# Altium schematic sheet SIM.SchDoc
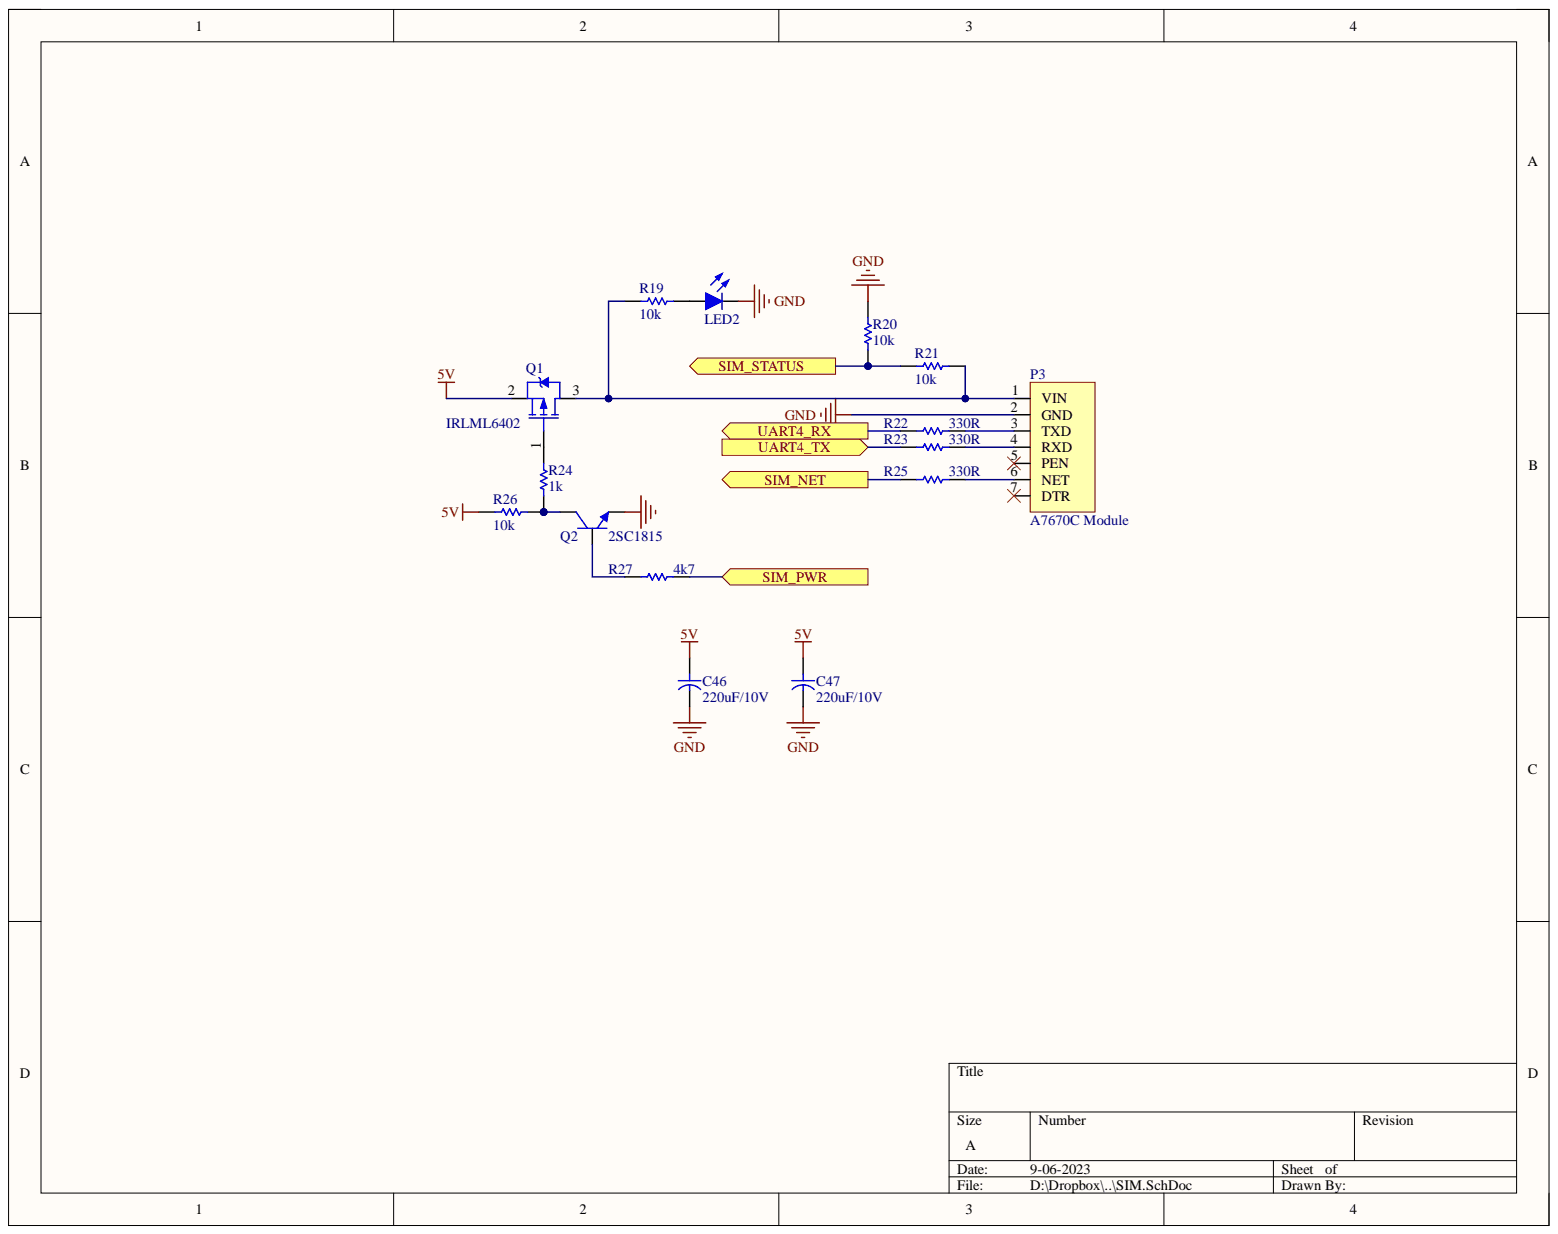
[diagram p0: SIM.SchDoc]

## Parts
C46  220uF/10V  [C SMD ALU (D)]
C47  220uF/10V  [C SMD ALU (D)]
LED2  Led-Red  [Led SMD 0603 RED]  (value not drawn)
P3  A7670C Module  [A7670C MODULE]
Q1  IRLML6402  [SOT-23]
Q2  2SC1815  [SOT-23]
R19  10k  [R 0603 (1608)]
R20  10k  [R 0603 (1608)]
R21  10k  [R 0603 (1608)]
R22  330R  [R 0603 (1608)]
R23  330R  [R 0603 (1608)]
R24  1k  [R 0603 (1608)]
R25  330R  [R 0603 (1608)]
R26  10k  [R 0603 (1608)]
R27  4k7  [R 0603 (1608)]

## Ports
SIM_NET, SIM_PWR, SIM_STATUS, UART4_RX, UART4_TX

## Nets
5V: C46.1 C47.1 Q1.2 R26.1
GND: C46.2 C47.2 LED2.2 P3.2(GND) Q2.2 R20.2
SIM_NET: R25.2 ; port SIM_NET
SIM_PWR: R27.2 ; port SIM_PWR
SIM_STATUS: R20.1 R21.1 ; port SIM_STATUS
UART4_RX: R22.2 ; port UART4_RX
UART4_TX: R23.2 ; port UART4_TX
NetLED2_1: LED2.1 R19.1
NetP3_1: P3.1(VIN) Q1.3 R19.2 R21.2
NetP3_3: P3.3(TXD) R22.1
NetP3_4: P3.4(RXD) R23.1
NetP3_6: P3.6(NET) R25.1
NetQ1_1: Q1.1 R24.1
NetQ2_1: Q2.1 R27.1
NetQ2_3: Q2.3 R24.2 R26.2

Not connected: P3 5(PEN) 7(DTR)

## Library data of the parts
C46 C47 = Capacitor: Capacitor pol; Component Kind: Standard; Library Name: BKIT4U_LIB.SchLib
LED2 = Led: Typical LED; Component Kind: Standard; Library Name: BKIT4U_LIB.SchLib
Q1 = MOSFET P-Channel: Component Kind: Standard; Description: MOSFET P-Channel; Library Name: BKIT4U_LIB.SchLib
Q2 = Transistor NPN: NPN Bipolar Transistor; Component Kind: Standard; Library Name: BKIT4U_LIB.SchLib
R19-R27 = Resistor: Component Kind: Standard; Description: Resistor; LatestRevisionDate: 17-Jul-2002; LatestRevisionNote: Re-released for DXP Platform.; Library Name: BKIT4U_LIB.SchLib; PackageReference: AXIAL-0.3; Published: 8-Jun-2000; Publisher: Altium Limited
P3 = A7670C Module: Component Kind: Standard; LatestRevisionDate: 17-Jul-2002; LatestRevisionNote: Re-released for DXP Platform.; Library Name: BKIT4U_LIB.SchLib; Publisher: Altium Limited
